# Altium schematic sheet Altaids_IO_Demo.SchDoc
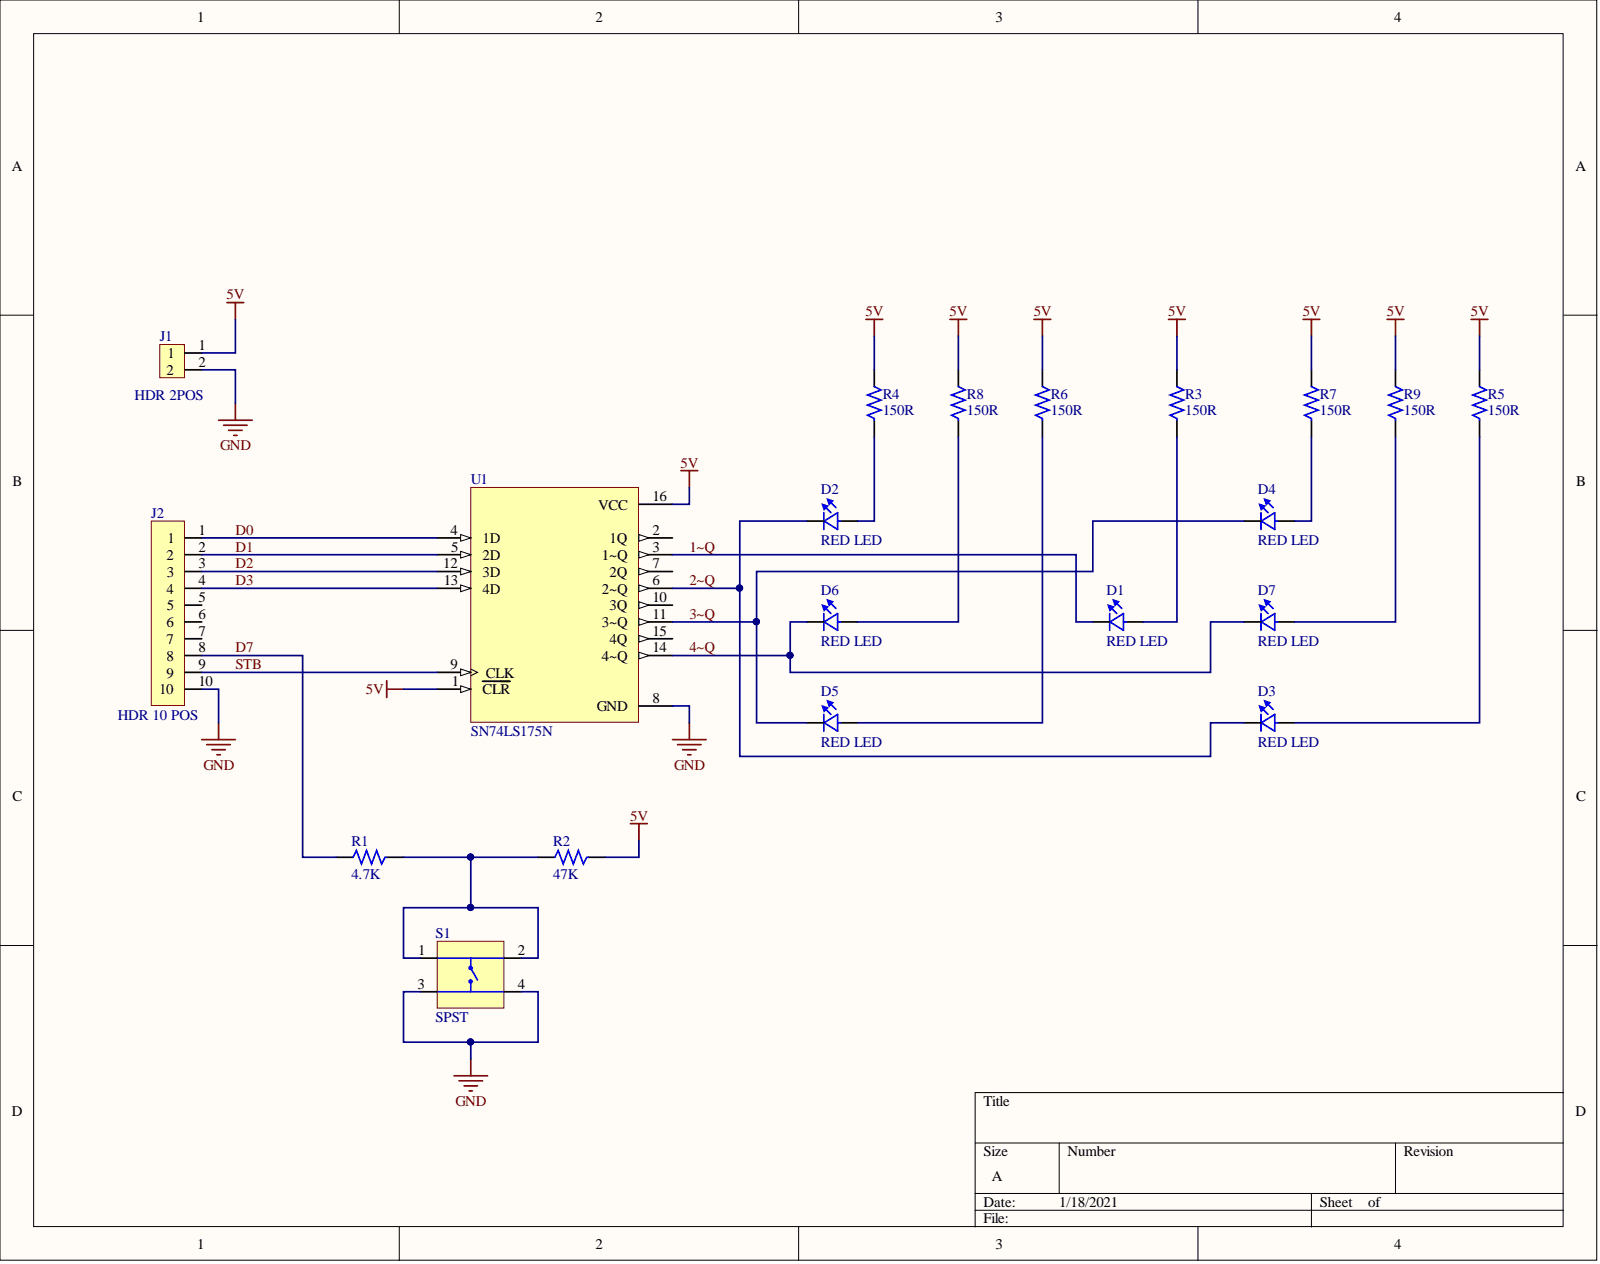
[diagram p0: Altaids_IO_Demo.SchDoc]

## Parts
D1  RED LED  [LED TH 5MM DIA 2.54mm Pitch]
D2  RED LED  [LED TH 5MM DIA 2.54mm Pitch]
D3  RED LED  [LED TH 5MM DIA 2.54mm Pitch]
D4  RED LED  [LED TH 5MM DIA 2.54mm Pitch]
D5  RED LED  [LED TH 5MM DIA 2.54mm Pitch]
D6  RED LED  [LED TH 5MM DIA 2.54mm Pitch]
D7  RED LED  [LED TH 5MM DIA 2.54mm Pitch]
J1  HDR 2POS  [HDR 2 POS 2.54 Male ST]
J2  HDR 10 POS  [HDR 10 POS 2.54 MALE ST]
R1  4.7K  [Res TH 460mil 11.68mm]
R2  47K  [Res TH 460mil 11.68mm]
R3  150R  [Res TH 460mil 11.68mm]
R4  150R  [Res TH 460mil 11.68mm]
R5  150R  [Res TH 460mil 11.68mm]
R6  150R  [Res TH 460mil 11.68mm]
R7  150R  [Res TH 460mil 11.68mm]
R8  150R  [Res TH 460mil 11.68mm]
R9  150R  [Res TH 460mil 11.68mm]
S1  SPST  [SW_2-1825910-7]
U1  SN74LS175N  [DIP794W45P254L1969H508Q16]

## Nets
5V: J1.1 R2.2 R3.1 R4.1 R5.1 R6.1 R7.1 R8.1 R9.1 U1.1 U1.16
GND: J1.2 J2.10 S1.3 S1.4 U1.8
1~Q: D1.1 U1.3
2~Q: D2.1 D3.1 U1.6
3~Q: D4.1 D5.1 U1.11
4~Q: D6.1 D7.1 U1.14
D0: J2.1 U1.4
D1: J2.2 U1.5
D2: J2.3 U1.12
D3: J2.4 U1.13
D7: J2.8 R1.1
STB: J2.9 U1.9
NetD1_2: D1.2 R3.2
NetD2_2: D2.2 R4.2
NetD3_2: D3.2 R5.2
NetD4_2: D4.2 R7.2
NetD5_2: D5.2 R6.2
NetD6_2: D6.2 R8.2
NetD7_2: D7.2 R9.2
NetR1_2: R1.2 R2.1 S1.1 S1.2

Not connected: J2 5 6 7; U1 2 7 10 15

## Library data of the parts
D1-D7 = LED Red TH 5mm Dia 1.9V 20mA: Standard LEDs - Through Hole WL-TMRW THT Mono Waterclr Rnd 5mm Red; Manufacturer Part Number 1: 151053RS03000; Brand: Wurth Elektronik; If - Forward Current: 100 mA; LED Size: T-1 3/4 (5 mm); Lens Shape: Dome; Lens Transparency: Water Clear; Luminous Intensity: 5000 mcd; Vf - Forward Voltage: 1.9 V; Viewing Angle: 30 deg; Wavelength/Color Temperature: 623 nm; Category 1: Standard LEDs - Through Hole; Component Kind: Standard; ComponentLink1Description: https://www.mouser.com/datasheet/2/445/151053RS03000-1715681.pdf; ComponentLink1URL: https://www.mouser.com/datasheet/2/445/151053RS03000-1715681.pdf; Configuration: -; Description 1: Standard LEDs - Through Hole WL-TMRW THT Mono Waterclr Rnd 5mm Red; Illumination Color: Red; Lens Dimensions: 5 mm x 8.6 mm; Library Name: Schematic_Library.SchLib; Manufacturer 1: Wurth Elektronik; Maximum Operating Temperature: + 85 C; Minimum Operating Temperature: - 40 C; Minimum Order Quantity: 1; Mounting Style: Through Hole; Package / Case: T-1 3/4 (5 mm); Packaging: Bulk; Pricing 1: 1=0.220, 10=0.213, 50=0.198, 100=0.171, 250=0.153 (USD); Product: Red LEDs; Product Type: LED - Standard; Reeling: False; RoHS 1: RoHS Compliant; RoHS - Mouser: Y; Series: WL-TMRW; Standard Pack Qty: 500; Stock 1: 602; Subcategory: LEDs; Supplier 1: Mouser; Supplier Part Number 1: 710-151053RS03000
J1 = HDR 2 pos 2.54mm TH male Straigt: Manufacturer Part Number 1: 61300211121; Brand: Wurth Elektronik; Category 1: Headers & Wire Housings; Current Rating: 3 A; Description 1: Headers & Wire Housings WR-PHD 2.54mm 2Pin THT Header; Insulation Resistance: 1 GOhms; Latching Type: Unlatched; Mating Post Length: 6 mm; Mounting Angle: Straight; Number of Positions: 2 Position; Voltage Rating: 250 V; Accessory Type: -; Application: Wire to Board; Component Kind: Standard; ComponentLink1Description: https://www.mouser.com/datasheet/2/445/61300211121-1717910.pdf; ComponentLink1URL: https://www.mouser.com/datasheet/2/445/61300211121-1717910.pdf; Contact Gender: Pin (Male); Contact Material: Copper Alloy; Contact Plating: Gold; Contact Type: -; Description: HDR 2 pos 2.54mm TH male Straigt; Flammability Rating: UL 94 V-0; Housing Color: Black; Housing Gender: -; Housing Material: Polybutylene Terephthalate (PBT); Library Name: Schematic_Library.SchLib; Manufacturer 1: Wurth Elektronik; Maximum Operating Temperature: + 125 C; Minimum Operating Temperature: - 40 C; Minimum Order Quantity: 1; Mounting Style: Straight Pin; Number of Rows: 1 Row; Packaging: Bulk; Pitch: 2.54 mm; Pricing 1: 1=0.120, 10=0.118, 25=0.116, 50=0.113, 100=0.106, 250=0.104, 500=0.090, 1000=0.089 (USD); Product: Headers; Product Type: Headers & Wire Housings; Reeling: False; RoHS 1: RoHS Compliant; RoHS - Mouser: Y; Row Spacing: -; Series: WR-PHD; Standard Pack Qty: 1000; Stock 1: 32934; Subcategory: Headers & Wire Housings; Supplier 1: Mouser; Supplier Part Number 1: 710-61300211121; Termination Post Length: 3 mm; Termination Style: Through Hole; Type: Pin Strip
J2 = HDR 10 Pos 2.54mm Male TH ST: WURTH ELEKTRONIK - 61301011121 - Pin Header, Board-to-Board, 2.54 mm, 1 Rows, 10 Contacts, Through Hole, WR-PHD Series; Brand: Wurth Electronics; Current Rating: 3 A; Insulation Resistance: 1000 MOhms; Mounting Angle: Horizontal; Number of Positions: 10 Position; Pitch: 2.54 mm; Voltage Rating: 250 V; Application: Board-to-Board; Component Kind: Standard; ComponentLink1Description: https://katalog.we-online.de/em/datasheet/6130xx11121.pdf?src-supplier=Digi-Key; ComponentLink1URL: https://katalog.we-online.de/em/datasheet/6130xx11121.pdf?src-supplier=Digi-Key; ComponentLink2Description: http://www.farnell.com/datasheets/1442175.pdf?src-supplier=Element14; ComponentLink2URL: http://www.farnell.com/datasheets/1442175.pdf?src-supplier=Element14; ComponentLink3Description: http://www.produktinfo.conrad.com/datenblaetter/1000000-1099999/001088187-da-01-en-WR_PHD__THT_STIFTLEISTE__61301011121.pdf?src-supplier=Conrad; ComponentLink3URL: http://www.produktinfo.conrad.com/datenblaetter/1000000-1099999/001088187-da-01-en-WR_PHD__THT_STIFTLEISTE__61301011121.pdf?src-supplier=Conrad; Contact Gender: Pin (Male); Contact Material: Copper Alloy; Contact Plating: Gold; Flammability Rating: UL 94 V-0; Housing Material: Polybutylene Terephthalate (PBT); Library Name: Schematic_Library.SchLib; Manufacturer: Wurth Electronics; Manufacturer Part Number: 61301011121; Mounting Style: Through Hole; Number of Rows: 1 Row; Operating Temperature Range: - 40 C to + 125 C; Packaging: Bulk; Pricing 1: 1=0.89, 10=0.874, 50=0.806, 100=0.739, 200=0.672 (USD); Product: Headers; Product Category: Headers & Wire Housings; RoHS: True; Series: WR-PHD; Stock 1: 2554; Supplier 1: Digi-Key; Supplier Part Number 1: 732-2670-ND; Termination Style: Solder; Type: Pin Strip
R1 = Res 4.7K TH 5% 1/4W 460" pitch: Res 4.7K TH 5% 1/4W; Manufacturer Part Number 1: CFR-25JR-52-4K7; Brand: Yageo; Category 1: Carbon Film Resistors - Through Hole; Description 1: Carbon Film Resistors - Through Hole 4.7K ohm 1/4W 5%; Lead Diameter: 0.55 mm; Power Rating: 250 mW (1/4 W); Resistance: 4.7 kOhms; Temperature Coefficient: 350 PPM / C; Voltage Rating: 250 V; Component Kind: Standard; ComponentLink1Description: https://www.mouser.com/datasheet/2/447/Yageo_LR_CFR_1-1670055.pdf; ComponentLink1URL: https://www.mouser.com/datasheet/2/447/Yageo_LR_CFR_1-1670055.pdf; Diameter: 2.4 mm; Features: -; Height: -; Lead Spacing: -; Length: 6.3 mm; Library Name: Schematic_Library.SchLib; Manufacturer 1: Yageo; Maximum Operating Temperature: + 155 C; Minimum Operating Temperature: - 55 C; Minimum Order Quantity: 1; Mounting Style: PCB Mount; Packaging: Cut Tape; Pricing 1: 1=0.100, 10=0.050, 100=0.018, 1000=0.013, 5000=0.010, 10000=0.008 (USD); Product Type: Carbon Film Resistors; Reeling: True; RoHS 1: RoHS Compliant; RoHS - Mouser: Y; Series: CFR; Standard Pack Qty: 5000; Stock 1: 50986; Subcategory: Resistors; Supplier 1: Mouser; Supplier Part Number 1: 603-CFR-25JR-524K7; Technology: Carbon Film; Termination Style: Axial; Tolerance: 5 %; Type: Normal & Miniature Style; Width: -
R2 = Res 47K TH 5% 1/4W 460" pitch: Res 47K TH 5% 1/4W; Manufacturer: Yageo; Manufacturer Part Number: CFR-25JR-52-47K; Catalog Drawings: Carbon Film Resistor    Derating Curve; Online Catalog: CFR Series; Other Names: 47K CR-1/4W-T 5%  47KQTR  CFR-25JR  47K  CFR-25JR-47K  CFR-25JR-5247K  CFR25JR5247K  CR-25-R-47K  RAB2473  RAB2473-ND; Power (Watts): 350ppm/°C; Product Training Modules: Leaded Resistors; Temperature Coefficient: Axial; Tolerance: 0.094" Dia x 0.248" L (2.40mm x 6.30mm); Unit PriceUSD: CFR; Category: Resistors; Component Kind: Standard; Composition: ±5%; Family: Through Hole Resistors; Features: Axial; Library Name: Schematic_Library.SchLib; Minimum Quantity: 47k; Number of Terminations: 2; Operating Temperature: -55°C ~ 155°C; Package / Case: Axial; Packaging: 0.25W, 1/4W; Pricing 1: 5000=0.0088, 10000=0.0079, 25000=0.0072, 50000=0.0068, 125000=0.0066 (USD); Quantity Available: Tape & Reel (TR)Alternate Packaging; Resistance (Ohms): 47k; Series: Carbon Film; Size / Dimension: 0.094" Dia x 0.248" L (2.40mm x 6.30mm); Standard Package: 5,000; Stock 1: 60000; Supplier 1: Digi-Key; Supplier Device Package: Axial; Supplier Part Number 1: 47KQTR-ND
R3-R9 = Res 150R TH 5% 1/4W 460" pitch: Res 150R TH 5% 1/4W; Manufacturer: Yageo; Manufacturer Part Number: CFR-25JR-52-150R; Catalog Drawings: Carbon Film Resistor    Derating Curve; Minimum Quantity: 150; Online Catalog: CFR Series; Other Names: 150E CR-1/4W-T 5%  150Q-ND  150QTR  CFR-25JR  150R  CFR-25JR-150R  CFR-25JR-52150R  CFR25JR52150R  CR-25-R-150  RAB2151; Power (Watts): 350ppm/°C; Product Training Modules: Leaded Resistors; Temperature Coefficient: Axial; Tolerance: 0.094" Dia x 0.248" L (2.40mm x 6.30mm); Unit PriceUSD: CFR; Category: Resistors; Component Kind: Standard; ComponentLink1Description: http://www.yageo.com/documents/recent/Yageo%20LR_CFR_2013.pdf?src-supplier=Avnet; ComponentLink1URL: http://www.yageo.com/documents/recent/Yageo%20LR_CFR_2013.pdf?src-supplier=Avnet; ComponentLink2Description: http://www.mouser.com/ds/2/447/Yageo%20LR_CFR_2013-540723.pdf?src-supplier=Mouser; ComponentLink2URL: http://www.mouser.com/ds/2/447/Yageo%20LR_CFR_2013-540723.pdf?src-supplier=Mouser; ComponentLink3Description: http://www.yageo.com/documents/recent/Yageo%20LR_CFR_2013.pdf?src-supplier=Digi-Key; ComponentLink3URL: http://www.yageo.com/documents/recent/Yageo%20LR_CFR_2013.pdf?src-supplier=Digi-Key; ComponentLink4Description: http://www.yageo.com/documents/recent/Leaded-R_CFR_2011.pdf?src-supplier=Avnet+Europe; ComponentLink4URL: http://www.yageo.com/documents/recent/Leaded-R_CFR_2011.pdf?src-supplier=Avnet+Europe; Composition: ±5%; Family: Through Hole Resistors; Features: Axial; Library Name: Schematic_Library.SchLib; Number of Terminations: 2; Operating Temperature: -55°C ~ 155°C; Package / Case: Axial; Packaging: 0.25W, 1/4W; Pricing 1: 5000=0.0088, 10000=0.0079, 25000=0.0072, 50000=0.0068, 125000=0.0066 (USD); Quantity Available: Tape & Reel (TR)Alternate Packaging; Resistance (Ohms): 150; Series: Carbon Film; Size / Dimension: 0.094" Dia x 0.248" L (2.40mm x 6.30mm); Standard Package: 5,000; Stock 1: 5000; Supplier 1: Digi-Key; Supplier Device Package: Axial; Supplier Part Number 1: 150QTR-ND
S1 = Tactile Switch TH SPST-NO Top Actuated long 6mm outline 2-1825910-7: TACTILE SWITCH, SPST, 0.05A, 24V, THT; Manufacturer: ALCOSWITCH - TE CONNECTIVITY; Illumination: Non Illuminated; Component Kind: Standard; Library Name: Schematic_Library.SchLib; Manufacturer Part Number: 2-1825910-7; Pricing 1: 1=0.12, 10=0.114, 25=0.1076, 100=0.0979, 250=0.092, 500=0.0842, 1000=0.0705, 5000=0.0646, 10000=0.0607 (USD); Product Range: -; Stock 1: 80290; Supplier 1: Digi-Key; Supplier Part Number 1: 450-1642-ND
U1 = SN74LS175N Flip Flop 1 Element D-Type 4 Bit Positive Edge 16-DIP (0.300, 7.62mm): Flip Flop High Speed Quadruple D-Type; Manufacturer: Texas Instruments; Bus Hold: No; Input Signal Type: Single-Ended; Logic Family: LS; Logic Function: D-Type Bus Interface; Number of Channels per Chip: 4; Number of Elements per Chip: 1; Number of Output Enables per Element: 0; Output Signal Type: Differential; Set/Reset: Master Reset; Typical Operating Supply Voltage: 5 V; Category: Flip Flop; Component Kind: Standard; ComponentLink1Description: http://www.ti.com/lit/ds/symlink/sn74ls175.pdf?src-supplier=Digi-Key; ComponentLink1URL: http://www.ti.com/lit/ds/symlink/sn74ls175.pdf?src-supplier=Digi-Key; ComponentLink2Description: http://www.ti.com/general/docs/lit/getliterature.tsp?genericPartNumber=SN54S175&&fileType=pdf&src-supplier=Avnet; ComponentLink2URL: http://www.ti.com/general/docs/lit/getliterature.tsp?genericPartNumber=SN54S175&&fileType=pdf&src-supplier=Avnet; ComponentLink3Description: http://www.farnell.com/datasheets/1967584.pdf?src-supplier=Element14; ComponentLink3URL: http://www.farnell.com/datasheets/1967584.pdf?src-supplier=Element14; ComponentLink4Description: https://www.distrelec.biz/Web/Downloads/56/13/kf645613.pdf?mime=application%2Fpdf&src-supplier=Distrelec; ComponentLink4URL: https://www.distrelec.biz/Web/Downloads/56/13/kf645613.pdf?mime=application%2Fpdf&src-supplier=Distrelec; ComponentLink5Description: https://www.verical.com/server-webapp/html/getDatasheet?partID=250178&src-supplier=Verical; ComponentLink5URL: https://www.verical.com/server-webapp/html/getDatasheet?partID=250178&src-supplier=Verical; Library Name: Schematic_Library.SchLib; Manufacturer Part Number: SN74LS175N; Number of Element Inputs: 4; Number of Element Outputs: 4; Operating Temperature: 0 to 70 °C; Package: 16PDIP; Packaging: Rail / Tube; Pricing 1: 1=0.93, 10=0.818, 25=0.7688, 100=0.6274, 250=0.5828, 500=0.496, 1000=0.3968, 2500=0.3596, 5000=0.3348 (USD); Stock 1: 2035; Supplier 1: Digi-Key; Supplier Part Number 1: 296-1648-5-ND
